# Altium schematic sheet Parametrischer_EQ.SchDoc
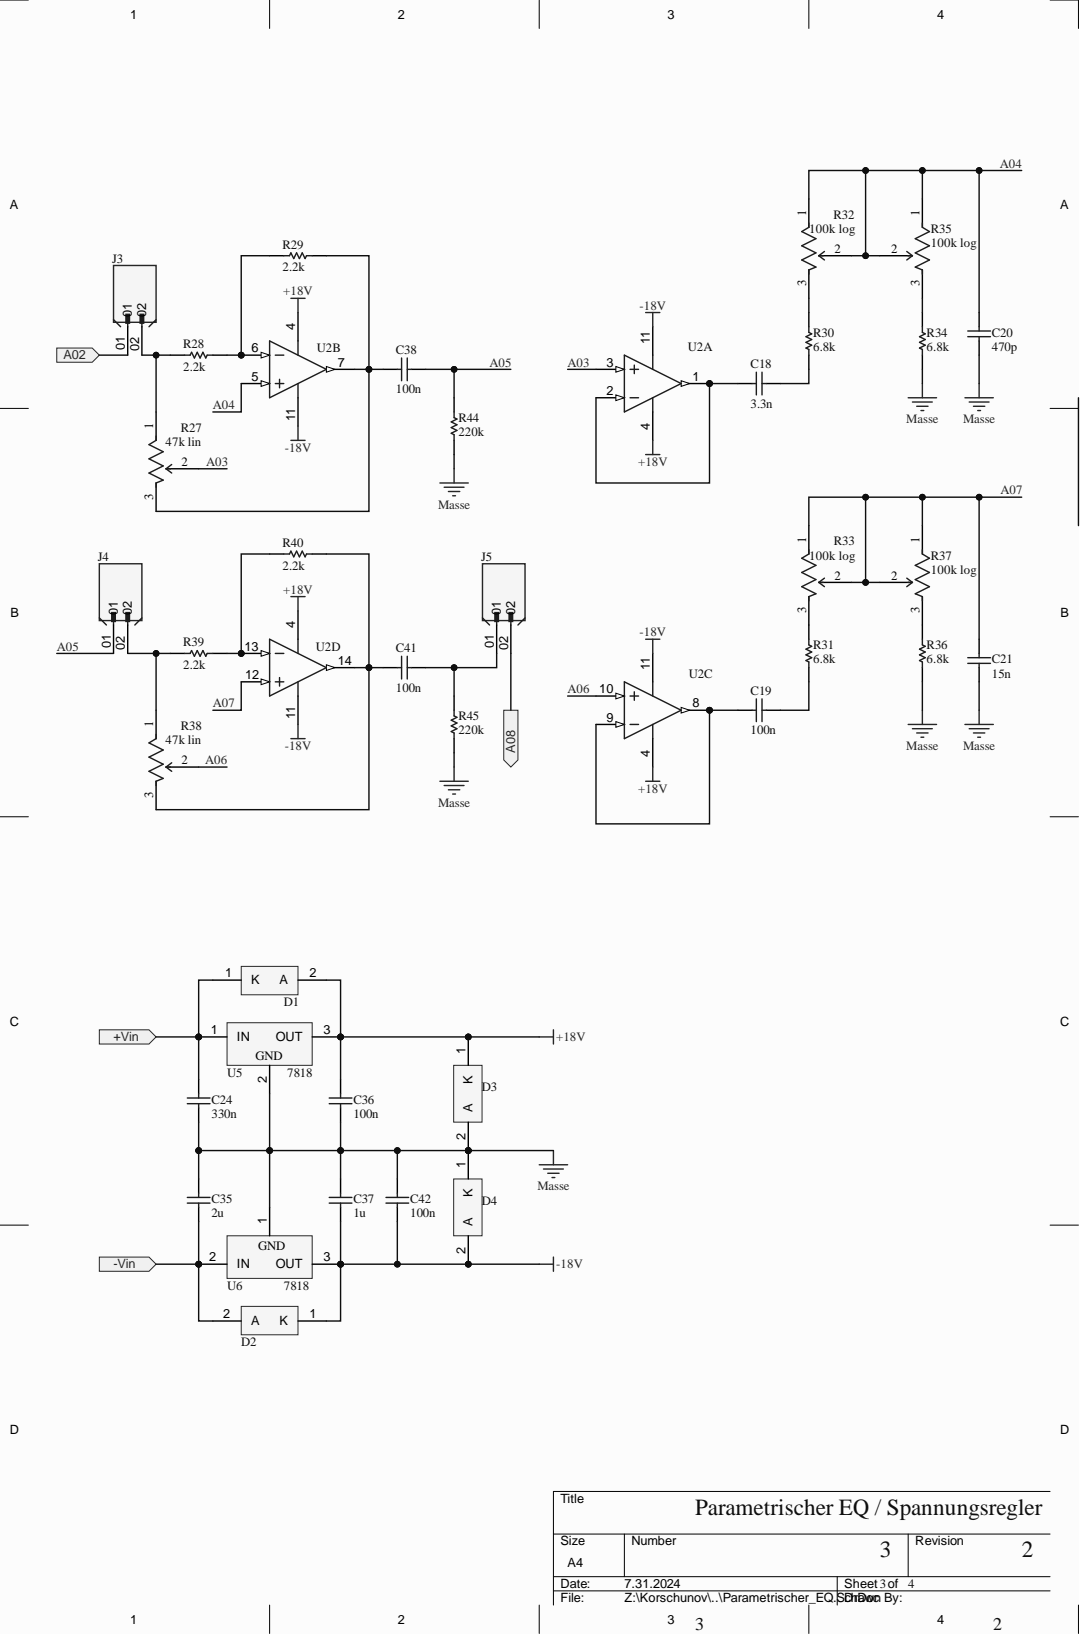
[diagram p0: Parametrischer_EQ.SchDoc]

## Parts
C18  3.3n  [0805]
C19  100n  [0805]
C20  470p  [0805]
C21  15n  [0805]
C24  330n  [0805]
C35  2u  [0805]
C36  100n  [0805]
C37  1u  [0805]
C38  100n  [0805]
C41  100n  [0805]
C42  100n  [0805]
D1  1N4001 Diode  [1N4001 Diode]  (value not drawn)
D2  1N4001 Diode  [1N4001 Diode]  (value not drawn)
D3  1N4001 Diode  [1N4001 Diode]  (value not drawn)
D4  1N4001 Diode  [1N4001 Diode]  (value not drawn)
J3  Connector x2  [Connector x2]  (value not drawn)
J4  Connector x2  [Connector x2]  (value not drawn)
J5  Connector x2  [Connector x2]  (value not drawn)
R27  47k lin  [Connector x3]
R28  2.2k  [0805]
R29  2.2k  [0805]
R30  6.8k  [0805]
R31  6.8k  [0805]
R32  100k log  [Connector x3]
R33  100k log  [Connector x3]
R34  6.8k  [0805]
R35  100k log  [Connector x3]
R36  6.8k  [0805]
R37  100k log  [Connector x3]
R38  47k lin  [Connector x3]
R39  2.2k  [0805]
R40  2.2k  [0805]
R44  220k  [0805]
R45  220k  [0805]
U2  OPA4134UA  [DIP14 IC Socket]  (value not drawn)  (parts A,B,C,D)
U5  7818  [TO220 Spannungsregler 78xx]
U6  7818  [TO220 Spannungsregler 79xx]

## Ports
+Vin, -Vin, A02, A08

## Nets
+18V: C36.2 D1.2 D3.1 U2.4 U5.3
-18V: C37.2 C42.1 D2.1 D4.2 U2.11 U6.3
Masse: C20.1 C21.2 C24.1 C35.1 C36.1 C37.1 C42.2 D3.2 D4.1 R34.1 R36.2 R44.1 R45.1 U5.2 U6.1
A02: J3.01 ; port A02
A03: R27.2 U2.3
A04: C20.2 R32.1 R32.2 R35.1 R35.2 U2.5
A05: C38.2 J4.01 R44.2
A06: R38.2 U2.10
A07: C21.1 R33.1 R33.2 R37.1 R37.2 U2.12
A08: J5.02 ; port A08
NetC18_1: C18.1 U2.1 U2.2
NetC18_2: C18.2 R30.1
NetC19_1: C19.1 R31.2
NetC19_2: C19.2 U2.8 U2.9
NetC24_2: C24.2 D1.1 U5.1
NetC35_2: C35.2 D2.2 U6.2
NetC38_1: C38.1 R27.3 R29.2 U2.7
NetC41_1: C41.1 R38.3 R40.2 U2.14
NetC41_2: C41.2 J5.01 R45.2
NetJ3_02: J3.02 R27.1 R28.1
NetJ4_02: J4.02 R38.1 R39.1
NetR28_2: R28.2 R29.1 U2.6
NetR30_2: R30.2 R32.3
NetR31_1: R31.1 R33.3
NetR34_2: R34.2 R35.3
NetR36_1: R36.1 R37.3
NetR39_2: R39.2 R40.1 U2.13

## Library data of the parts
C18-C21 C24 C35-C38 C41 C42 = 0805 Kondensator: Component Kind: Standard; Description: 0805 Kondensator; Library Name: Bauteile.SchLib
  C18: Value: 3.3n
  C19 C36 C38 C41 C42: Value: 100n
  C20: Value: 470p
  C21: Value: 15n
  C24: Value: 330n
  C35: Value: 2u
  C37: Value: 1u
D1-D4 = 1N4001 Diode: Standard Recovery Rectifier, 2-Pin Axial_Lead, Pb-Free, Bulk Bag; Manufacturer: On Semiconductor; IFSM Max (A): 30; IO(rec) Max (A): 1; VRRM Max (V): 50; Component Kind: Standard; ComponentLink1Description: Manufacturer URL; ComponentLink1URL: http://www.onsemi.com/; ComponentLink2Description: Datasheet; ComponentLink2URL: http://www.onsemi.com/pub_link/Collateral/1N4001-D.PDF; ComponentLink3Description: Package Specification; ComponentLink3URL: http://www.onsemi.cn/pub_link/Collateral/59-10.PDF; DatasheetVersion: Rev. 13; Mounting Technology: Through Hole; PackageDescription: 2-Pin, Axial Lead, Diameter 2.35 mm; PackageReference: AXIAL_LEAD-2-59-10; PackageVersion: Rev. U, 02/2005; Packing: Bulk Bag; PartNumber: 1N4001; RoHS: TRUE; Type: Standard Recovery; Library Name: Bauteile.SchLib
J3-J5 = Connector x2: Connector Header Through Hole 2 position 0.100 (2.54mm); MF: Wurth Elektronik; MP: 61300211121; Check_prices: https://www.snapeda.com/parts/61300211121/Wurth+Elektronik/view-part/?ref=eda; Component Kind: Standard; Package: None; SnapEDA_Link: https://www.snapeda.com/parts/61300211121/Wurth+Elektronik/view-part/?ref=snap; Library Name: Bauteile.SchLib
R27 R32 R33 R35 R37 R38 = Potentiometer Buchsenleiste: Potentiometer; Component Kind: Standard; Library Name: Bauteile.SchLib
  R27 R38: Value: 47k lin
  R32 R33 R35 R37: Value: 100k log
R28-R31 R34 R36 R39 R40 R44 R45 = 0805 Widerstand: Component Kind: Standard; Description: 0805 Widerstand; Library Name: Bauteile.SchLib
  R28 R29 R39 R40: Value: 2.2k
  R30 R31 R34 R36: Value: 6.8k
  R44 R45: Value: 220k
U2 = SOIC OPA4134UA: SoundPlus(TM) High Performance Audio Operational Amplifier, 5 to 36 V, -55 to 125 degC, 14-pin SOIC (D14), Green (RoHS & no Sb/Br); Manufacturer: Texas Instruments; GBW(Typ)(MHz): 8; Iq per channel(Max)(mA): 5; Number of Channels: 4; Vmax(V): 36; Code_JEDEC: MS-012; Component Kind: Standard; ComponentLink1Description: Manufacturer URL; ComponentLink1URL: http://www.ti.com/; ComponentLink2Description: Datasheet; ComponentLink2URL: http://www.ti.com/general/docs/lit/getliterature.tsp?genericPartNumber=OPA4134&fileType=pdf; ComponentLink3Description: Package Specification; ComponentLink3URL: http://www.ti.com/lit/ml/mpds177g/mpds177g.pdf; DatasheetVersion: SBOS058; Eco-Plan: Green (RoHS & no Sb/Br); Mounting Technology: Surface Mount; PackageDescription: 14-Pin Plastic Small Outline, Body 8.65 x 3.9 mm, Pitch 1.27 mm; PackageReference: D0014A; PackageVersion: 4040047-5/M, 06/2011; PartNumber: OPA4134UA; SubFamily: Audio Operational Amplifier; Vmin(V): 5; Vn at Flatband(Typ)(nV/rtHz): 8; Library Name: Bauteile.SchLib
U5 = TO 220 Spannungsregler 78xx: Voltage Regulator, 3-Pin TO-220; Component Kind: Standard; Typ: 7818; Library Name: Bauteile.SchLib
U6 = TO 220 Spannungsregler 79xx: Voltage Regulator, 3-Pin TO-220; Component Kind: Standard; Typ: 7818; Library Name: Bauteile.SchLib
